# Altium schematic sheet Cacto_Sch.SchDoc
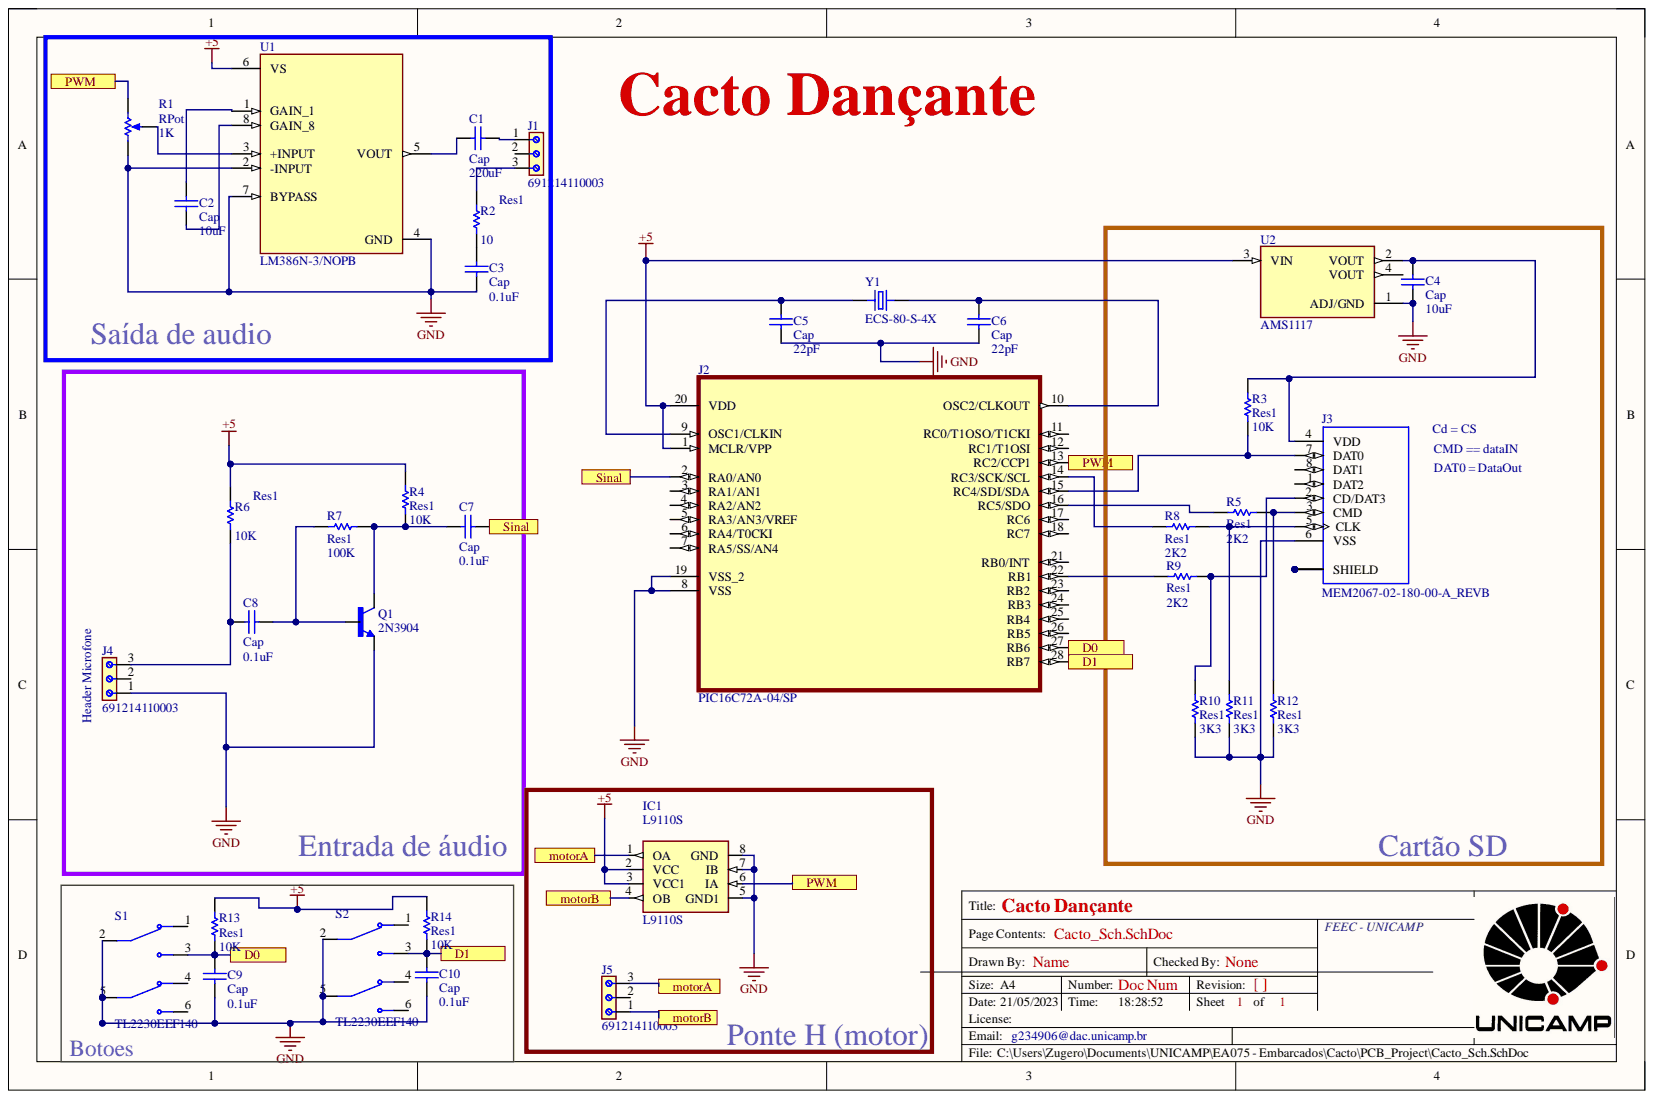
[diagram p0: Cacto_Sch.SchDoc]

## Parts
C1  Cap  value 220uF  [RAD-0.3]
C2  Cap  value 10uF  [RAD-0.3]
C3  Cap  value 0.1uF  [RAD-0.3]
C4  Cap  value 10uF  [RAD-0.3]
C5  Cap  value 22pF  [RAD-0.3]
C6  Cap  value 22pF  [RAD-0.3]
C7  Cap  value 0.1uF  [RAD-0.3]
C8  Cap  value 0.1uF  [RAD-0.3]
C9  Cap  value 0.1uF  [RAD-0.3]
C10  Cap  value 0.1uF  [RAD-0.3]
IC1  L9110S  [SOIC127P600X150-8]
J1  691214110003  [691214110003]
J2  PIC16C72A-04/SP  [DIP254P762X406-28]
J3  MEM2067-02-180-00-A_REVB  [GCT_MEM2067-02-180-00-A_REVB]
J4  691214110003  [691214110003]
J5  691214110003  [691214110003]
Q1  2N3904  [TO92127P495H495-3]
R1  RPot  value 1K  [VR5]
R2  Res1  value 10  [AXIAL-0.3]
R3  Res1  value 10K  [AXIAL-0.3]
R4  Res1  value 10K  [AXIAL-0.3]
R5  Res1  value 2K2  [AXIAL-0.3]
R6  Res1  value 10K  [AXIAL-0.3]
R7  Res1  value 100K  [AXIAL-0.3]
R8  Res1  value 2K2  [AXIAL-0.3]
R9  Res1  value 2K2  [AXIAL-0.3]
R10  Res1  value 3K3  [AXIAL-0.3]
R11  Res1  value 3K3  [AXIAL-0.3]
R12  Res1  value 3K3  [AXIAL-0.3]
R13  Res1  value 10K  [AXIAL-0.3]
R14  Res1  value 10K  [AXIAL-0.3]
S1  TL2230EEF140  [SW_TL2230EEF140]
S2  TL2230EEF140  [SW_TL2230EEF140]
U1  LM386N-3/NOPB  [DIP794W45P254L959H508Q8]
U2  AMS1117  [SOT229P700X180-4N]
Y1  ECS-80-S-4X  [XTAL_ECS-80-S-4X]

## Ports
D0, D1, motorA, motorB, PWM, Sinal

## Nets
+5: IC1.2(VCC) IC1.3(VCC1) J2.1(MCLR/VPP) J2.20(VDD) R4.2 R6.2 R13.2 R14.2 U1.6(VS) U2.3(VIN)
GND: C3.1 C4.1 C5.1 C6.1 C9.1 C10.1 IC1.5(GND1) IC1.7(IB) IC1.8(GND) J2.8(VSS) J2.19(VSS_2) J3.6(VSS) J4.1 Q1.1 R1.1 R10.1 R11.1 R12.1 S1.2 S1.5 S2.2 S2.5 U1.2(-INPUT) U1.4(GND) U1.7(BYPASS) U2.1(ADJ/GND)
D0: C9.2 J2.27(RB6) R13.1 S1.3 ; port D0
D1: C10.2 J2.28(RB7) R14.1 S2.3 ; port D1
motorA: IC1.1(OA) J5.3 ; port motorA
motorB: IC1.4(OB) J5.1 ; port motorB
PWM: IC1.6(IA) J2.13(RC2/CCP1) R1.2 ; port PWM
Sinal: C7.2 J2.2(RA0/AN0) ; port Sinal
NetC1_1: C1.1 J1.1
NetC1_2: C1.2 U1.5(VOUT)
NetC2_1: C2.1 U1.8(GAIN_8)
NetC2_2: C2.2 U1.1(GAIN_1)
NetC3_2: C3.2 R2.1
NetC4_2: C4.2 J3.4(VDD) R3.1 U2.2(VOUT)
NetC5_2: C5.2 J2.9(OSC1/CLKIN) Y1.2
NetC6_2: C6.2 J2.10(OSC2/CLKOUT) Y1.1
NetC7_1: C7.1 Q1.3 R4.1 R7.1
NetC8_1: C8.1 J4.3 R6.1
NetC8_2: C8.2 Q1.2 R7.2
NetJ1_3: J1.3 R2.2
NetJ2_14: J2.14(RC3/SCK/SCL) R8.1
NetJ2_15: J2.15(RC4/SDI/SDA) J3.7(DAT0) R3.2
NetJ2_16: J2.16(RC5/SDO) R5.1
NetJ2_22: J2.22(RB1) R9.1
NetJ3_2: J3.2(CD/DAT3) R9.2 R10.2
NetJ3_3: J3.3(CMD) R5.2 R12.2
NetJ3_5: J3.5(CLK) R8.2 R11.2
NetJ3_9: J3.9(SHIELD) J3.10 J3.11 J3.12
NetR1_3: R1.3 U1.3(+INPUT)

Not connected: J1 2; J2 3(RA1/AN1) 4(RA2/AN2) 5(RA3/AN3/VREF) 6(RA4/T0CKI) 7(RA5/SS/AN4) 11(RC0/T1OSO/T1CKI) 12(RC1/T1OSI) 17(RC6) 18(RC7) 21(RB0/INT) 23(RB2) 24(RB3) 25(RB4) 26(RB5); J3 1(DAT2) 8(DAT1); J4 2; J5 2; S1 1 4 6; S2 1 4 6; U2 4(VOUT)

## Library data of the parts
C1 = Cap: Capacitor; Value: 220uF; Component Kind: Standard; LatestRevisionDate: 17-Jul-2002; LatestRevisionNote: Re-released for DXP Platform.; Library Name: Miscellaneous Devices.IntLib; PackageDescription: Radial Cap, Thru-Hole; 2 Leads; 0.3 in Pin Spacing; PackageReference: RAD-0.3; Published: 8-Jun-2000; Signal Integrity: Cap; Simulation: CAP; Publisher: Altium Limited
C2-C10 = Cap: Capacitor; Component Kind: Standard; LatestRevisionDate: 17-Jul-2002; LatestRevisionNote: Re-released for DXP Platform.; Library Name: Miscellaneous Devices.IntLib; PackageDescription: Radial Cap, Thru-Hole; 2 Leads; 0.3 in Pin Spacing; PackageReference: RAD-0.3; Published: 8-Jun-2000; Signal Integrity: Cap; Simulation: CAP; Publisher: Altium Limited
IC1 = L9110S: Buck-Boost Switching Regulator IC Positive Adjustable 1V 1 Output 1.2A 8-SOIC (0.154, 3.90mm Width); MF: Renesas Electronics; Availability: Not in stock; Check_prices: https://www.snapeda.com/parts/L9110S/Renesas/view-part/?ref=eda; Component Kind: Standard; Library Name: L9110S.IntLib; MP: L9110S; Package: None; Price: None; SnapEDA_Link: https://www.snapeda.com/parts/L9110S/Renesas/view-part/?ref=snap
J1 J4 J5 = 691214110003: 3 Position Wire to Board Terminal Block Horizontal with Board 0.138 (3.50mm) Through Hole; MF: Wurth Elektronik; Availability: In Stock; Check_prices: https://www.snapeda.com/parts/691214110003/Wurth+Elektronik/view-part/?ref=eda; Component Kind: Standard; Library Name: 691214110003.IntLib; MP: 691214110003; Package: None; Price: None; SnapEDA_Link: https://www.snapeda.com/parts/691214110003/Wurth+Elektronik/view-part/?ref=snap
J2 = PIC16C72A-04/SP: 8-Bit CMOS Microcontrollers; Availability: Unavailable; Component Kind: Standard; Library Name: PIC16C72A-04_SP.IntLib; MP: PIC16C72A-04/SP; Package: None; Price: None
J3 = MEM2067-02-180-00-A_REVB: Micro SD Memory Card ConnectorSMT, Hinged, 1.80mm Profile; Availability: Unavailable; Component Kind: Standard; Library Name: MEM2067-02-180-00-A_REVB.IntLib; MP: MEM2067-02-180-00-A_REVB; Package: None; Price: None
Q1 = 2N3904: TRANS NPN 40V 200MA TO92-3; MF: Rectron; Availability: In Stock; Check_prices: https://www.snapeda.com/parts/2N3904/Rectron/view-part/?ref=eda; Component Kind: Standard; Library Name: 2N3904.IntLib; MP: 2N3904; Package: TO-226-3 Rectron; Price: None; Purchase-URL: https://pricing.snapeda.com/search/part/2N3904/?ref=eda; SnapEDA_Link: https://www.snapeda.com/parts/2N3904/Rectron/view-part/?ref=snap
R1 = RPot: Potentiometer; Set Position: 0.5; Sim Note: Enter the Resistance value and the set point into parameter fields.; Component Kind: Standard; LatestRevisionDate: 17-Jul-2002; LatestRevisionNote: Re-released for DXP Platform.; Library Name: Miscellaneous Devices.IntLib; PackageDescription: Variable Resistor, Thru-Hole; 3 Leads; PackageReference: VR5; Published: 8-Jun-2000; Simulation: POT; Publisher: Altium Limited
R2-R14 = Res1: Resistor; Component Kind: Standard; LatestRevisionDate: 17-Jul-2002; LatestRevisionNote: Re-released for DXP Platform.; Library Name: Miscellaneous Devices.IntLib; PackageDescription: Axial Device, Thru-Hole; 2 Leads; 0.3 in Pin Spacing; PackageReference: AXIAL-0.3; Published: 8-Jun-2000; Signal Integrity: Res; Simulation: RESISTOR; Publisher: Altium Limited
S1 S2 = TL2230EEF140: Pushbutton Switch DPDT Standard Through Hole; MF: E-Switch; Availability: In Stock; Check_prices: https://www.snapeda.com/parts/TL2230EEF140/E-Switch/view-part/?ref=eda; Component Kind: Standard; Library Name: TL2230EEF140.IntLib; MP: TL2230EEF140; Package: None; Price: None; Purchase-URL: https://pricing.snapeda.com/search/part/TL2230EEF140/?ref=eda; SnapEDA_Link: https://www.snapeda.com/parts/TL2230EEF140/E-Switch/view-part/?ref=snap
U2 = AMS1117: 1A LOW DROPOUT VOLTAGE REGULATOR SOT-223; MF: Advanced Monolithic Systems; Availability: Not in stock; Check_prices: https://www.snapeda.com/parts/AMS1117/Advanced+Monolithic+Systems/view-part/?ref=eda; Component Kind: Standard; Library Name: AMS1117.IntLib; MP: AMS1117; Package: SOT-223 Seeed Technology; Price: None; SnapEDA_Link: https://www.snapeda.com/parts/AMS1117/Advanced+Monolithic+Systems/view-part/?ref=snap
Y1 = ECS-80-S-4X: CRYSTAL T/H; Availability: Unavailable; Component Kind: Standard; Library Name: ECS-80-S-4X.IntLib; MP: ECS-80-S-4X; Package: None; Price: None
U1 = LM386N-3/NOPB: Availability: Unavailable; Component Kind: Standard; Library Name: LM386N-3_NOPB.IntLib; MP: LM386N-3/NOPB; Package: None; Price: None
